# Altium schematic sheet pca64167.SchDoc
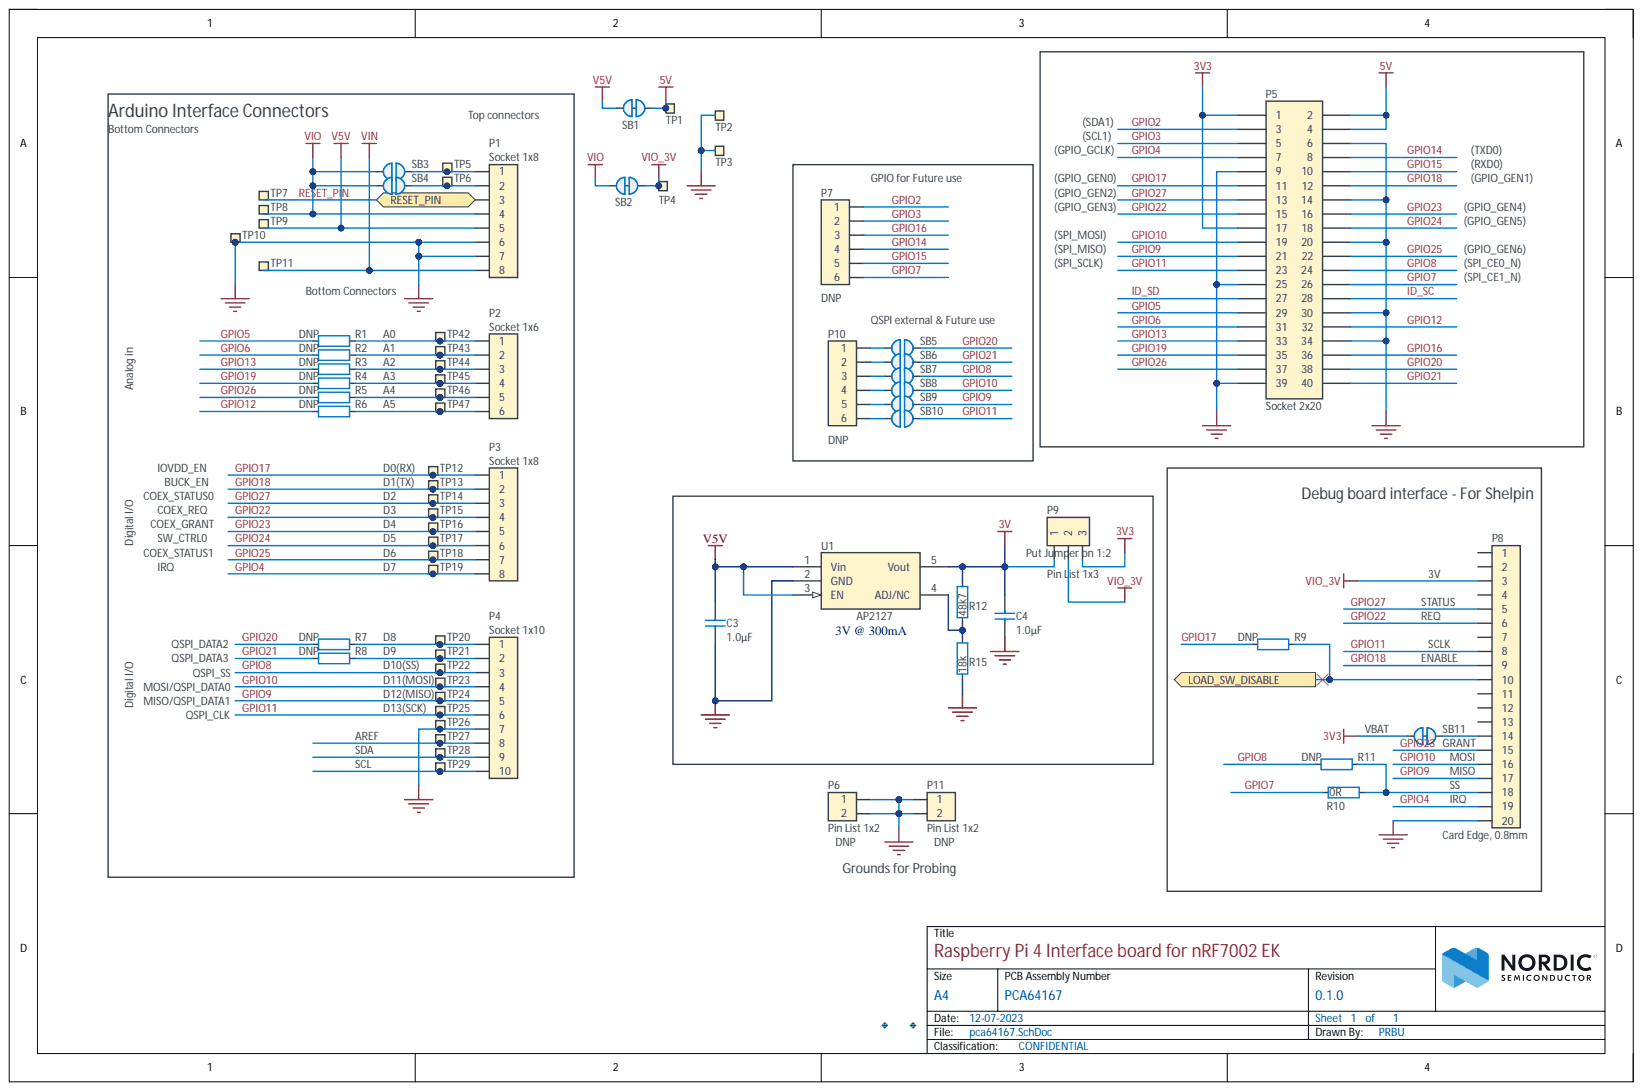
[diagram p0: pca64167.SchDoc]

## Parts
C3  1.0µF  [CAPC1005X04L]
C4  1.0µF  [CAPC1005X04L]
Fid1  Fiducial mark  [Fiducial]  (value not drawn)
Fid2  Fiducial mark  [Fiducial]  (value not drawn)
P1  Socket 1x8  [SOCKET_1x8_SMD]
P2  Socket 1x6  [SOCKET_1x6_SMD]
P3  Socket 1x8  [SOCKET_1x8_SMD]
P4  Socket 1x10  [SOCKET_1x10_SMD]
P5  Socket 2x20  [SOCKET_2x20_SMD-2.54mm]
P6  Pin List 1x2  Assembly: DNP  [LIST_1x2]
P7  Assembly: DNP  [HDR_2x3]  (hidden comment: Pin Header 2x3)
P8  Card Edge, 0.8mm  [10056847-101LF]
P9  Pin List 1x3  [LIST_1x3]
P10  Assembly: DNP  [HDR_2x3]  (hidden comment: Pin Header 2x3)
P11  Pin List 1x2  Assembly: DNP  [LIST_1x2]
R1  Assembly: DNP  [RESC1005X04L]  (hidden comment: 0R)
R2  Assembly: DNP  [RESC1005X04L]  (hidden comment: 0R)
R3  Assembly: DNP  [RESC1005X04L]  (hidden comment: 0R)
R4  Assembly: DNP  [RESC1005X04L]  (hidden comment: 0R)
R5  Assembly: DNP  [RESC1005X04L]  (hidden comment: 0R)
R6  Assembly: DNP  [RESC1005X04L]  (hidden comment: 0R)
R7  Assembly: DNP  [RESC1005X04L]  (hidden comment: 0R)
R8  Assembly: DNP  [RESC1005X04L]  (hidden comment: 0R)
R9  Assembly: DNP  [RESC1005X04L]  (hidden comment: 0R)
R10  0R  [RESC1005X04L]
R11  0R  Assembly: DNP  [RESC1005X04L]
R12  48k7  [RESC1005X04L]
R15  18k  [RESC1005X04L]
SB1  Solderbridge  [Solderbridge_Shorted_Power]  (value not drawn)
SB2  Solderbridge  [Solderbridge_Shorted_Power]  (value not drawn)
SB3  Solderbridge  [Solderbridge_0.4]  (value not drawn)  (no BOM)
SB4  Solderbridge  [Solderbridge_0.4]  (value not drawn)  (no BOM)
SB5  Solderbridge  [Solderbridge_0.4]  (value not drawn)  (no BOM)
SB6  Solderbridge  [Solderbridge_0.4]  (value not drawn)  (no BOM)
SB7  Solderbridge  [Solderbridge_0.4]  (value not drawn)  (no BOM)
SB8  Solderbridge  [Solderbridge_0.4]  (value not drawn)  (no BOM)
SB9  Solderbridge  [Solderbridge_0.4]  (value not drawn)  (no BOM)
SB10  Solderbridge  [Solderbridge_0.4]  (value not drawn)  (no BOM)
SB11  Solderbridge  [Solderbridge_Shorted_Power]  (value not drawn)
TP1  1.0mm,SMD  [TP_SMD_1.0mmX1.0mm]  (value not drawn)  (no BOM)
TP2  1.0mm,SMD  [TP_SMD_1.0mmX1.0mm]  (value not drawn)  (no BOM)
TP3  1.0mm,SMD  [TP_SMD_1.0mmX1.0mm]  (value not drawn)  (no BOM)
TP4  1.0mm,SMD  [TP_SMD_1.0mmX1.0mm]  (value not drawn)  (no BOM)
TP5  Ø1.5mm,SMD  [TP_SMD_D1.5mm]  (value not drawn)  (no BOM)
TP6  Ø1.5mm,SMD  [TP_SMD_D1.5mm]  (value not drawn)  (no BOM)
TP7  Ø1.5mm,SMD  [TP_SMD_D1.5mm]  (value not drawn)  (no BOM)
TP8  Ø1.5mm,SMD  [TP_SMD_D1.5mm]  (value not drawn)  (no BOM)
TP9  Ø1.5mm,SMD  [TP_SMD_D1.5mm]  (value not drawn)  (no BOM)
TP10  Ø1.5mm,SMD  [TP_SMD_D1.5mm]  (value not drawn)  (no BOM)
TP11  Ø1.5mm,SMD  [TP_SMD_D1.5mm]  (value not drawn)  (no BOM)
TP12  Ø1.5mm,SMD  [TP_SMD_D1.5mm]  (value not drawn)  (no BOM)
TP13  Ø1.5mm,SMD  [TP_SMD_D1.5mm]  (value not drawn)  (no BOM)
TP14  Ø1.5mm,SMD  [TP_SMD_D1.5mm]  (value not drawn)  (no BOM)
TP15  Ø1.5mm,SMD  [TP_SMD_D1.5mm]  (value not drawn)  (no BOM)
TP16  Ø1.5mm,SMD  [TP_SMD_D1.5mm]  (value not drawn)  (no BOM)
TP17  Ø1.5mm,SMD  [TP_SMD_D1.5mm]  (value not drawn)  (no BOM)
TP18  Ø1.5mm,SMD  [TP_SMD_D1.5mm]  (value not drawn)  (no BOM)
TP19  Ø1.5mm,SMD  [TP_SMD_D1.5mm]  (value not drawn)  (no BOM)
TP20  Ø1.5mm,SMD  [TP_SMD_D1.5mm]  (value not drawn)  (no BOM)
TP21  Ø1.5mm,SMD  [TP_SMD_D1.5mm]  (value not drawn)  (no BOM)
TP22  Ø1.5mm,SMD  [TP_SMD_D1.5mm]  (value not drawn)  (no BOM)
TP23  Ø1.5mm,SMD  [TP_SMD_D1.5mm]  (value not drawn)  (no BOM)
TP24  Ø1.5mm,SMD  [TP_SMD_D1.5mm]  (value not drawn)  (no BOM)
TP25  Ø1.5mm,SMD  [TP_SMD_D1.5mm]  (value not drawn)  (no BOM)
TP26  Ø1.5mm,SMD  [TP_SMD_D1.5mm]  (value not drawn)  (no BOM)
TP27  Ø1.5mm,SMD  [TP_SMD_D1.5mm]  (value not drawn)  (no BOM)
TP28  Ø1.5mm,SMD  [TP_SMD_D1.5mm]  (value not drawn)  (no BOM)
TP29  Ø1.5mm,SMD  [TP_SMD_D1.5mm]  (value not drawn)  (no BOM)
TP42  Ø1.5mm,SMD  [TP_SMD_D1.5mm]  (value not drawn)  (no BOM)
TP43  Ø1.5mm,SMD  [TP_SMD_D1.5mm]  (value not drawn)  (no BOM)
TP44  Ø1.5mm,SMD  [TP_SMD_D1.5mm]  (value not drawn)  (no BOM)
TP45  Ø1.5mm,SMD  [TP_SMD_D1.5mm]  (value not drawn)  (no BOM)
TP46  Ø1.5mm,SMD  [TP_SMD_D1.5mm]  (value not drawn)  (no BOM)
TP47  Ø1.5mm,SMD  [TP_SMD_D1.5mm]  (value not drawn)  (no BOM)
U1  AP2127  [SOT95P282X145-5N]

## Ports
LOAD_SW_DISABLE, RESET_PIN

## Nets
3V: C4.2 P9.1 R12.2 U1.5(Vout)
3V3: P5.1 P5.17 P9.3 SB11.2
5V: P5.2 P5.4 SB1.1 TP1.1
GND: C3.1 C4.1 P1.6 P1.7 P4.7 P5.6 P5.9 P5.14 P5.20 P5.25 P5.30 P5.34 P5.39 P6.1 P6.2 P8.20 P11.1 P11.2 R15.1 TP2.1 TP3.1 TP10.1 TP26.1 U1.2(GND)
V5V: C3.2 P1.5 SB1.2 TP9.1 U1.1(Vin) U1.3(EN)
VIN: P1.8 TP11.1
VIO: P1.4 SB2.2 SB3.2 SB4.2 TP8.1
VIO_3V: P8.3 P9.2 SB2.1 TP4.1
GPIO2: P5.3 P7.1
GPIO3: P5.5 P7.2
GPIO4: P3.8 P5.7 P8.19 TP19.1
GPIO5: P5.29 R1.1
GPIO6: P5.31 R2.1
GPIO7: P5.26 P7.6 R10.1
GPIO8: P4.3 P5.24 R11.1 SB7.1 TP22.1
GPIO9: P4.5 P5.21 P8.17 SB9.1 TP24.1
GPIO10: P4.4 P5.19 P8.16 SB8.1 TP23.1
GPIO11: P4.6 P5.23 P8.8 SB10.1 TP25.1
GPIO12: P5.32 R6.1
GPIO13: P5.33 R3.1
GPIO14: P5.8 P7.4
GPIO15: P5.10 P7.5
GPIO16: P5.36 P7.3
GPIO17: P3.1 P5.11 R9.1 TP12.1
GPIO18: P3.2 P5.12 P8.9 TP13.1
GPIO19: P5.35 R4.1
GPIO20: P5.38 R7.1 SB5.1
GPIO21: P5.40 R8.1 SB6.1
GPIO22: P3.4 P5.15 P8.6 TP15.1
GPIO23: P3.5 P5.16 P8.15 TP16.1
GPIO24: P3.6 P5.18 TP17.1
GPIO25: P3.7 P5.22 TP18.1
GPIO26: P5.37 R5.1
GPIO27: P3.3 P5.13 P8.5 TP14.1
ID_SC: P5.28
ID_SD: P5.27
LOAD_SW_DISABLE: P8.10 R9.2 ; port LOAD_SW_DISABLE
RESET_PIN: P1.3 TP7.1 ; port RESET_PIN
NetP1_1: P1.1 SB3.1 TP5.1
NetP1_2: P1.2 SB4.1 TP6.1
NetP2_1: P2.1 R1.2 TP42.1
NetP2_2: P2.2 R2.2 TP43.1
NetP2_3: P2.3 R3.2 TP44.1
NetP2_4: P2.4 R4.2 TP45.1
NetP2_5: P2.5 R5.2 TP46.1
NetP2_6: P2.6 R6.2 TP47.1
NetP4_1: P4.1 R7.2 TP20.1
NetP4_2: P4.2 R8.2 TP21.1
NetP4_8: P4.8 TP27.1
NetP4_9: P4.9 TP28.1
NetP4_10: P4.10 TP29.1
NetP8_14: P8.14 SB11.1
NetP8_18: P8.18 R10.2 R11.2
NetP10_1: P10.1 SB5.2
NetP10_2: P10.2 SB6.2
NetP10_3: P10.3 SB7.2
NetP10_4: P10.4 SB8.2
NetP10_5: P10.5 SB9.2
NetP10_6: P10.6 SB10.2
NetR12_1: R12.1 R15.2 U1.4(ADJ/NC)

Not connected: P8 1 2 4 7 11 12 13

## Library data of the parts
C3 = C0402-1µ0-16V-X6S-GRM155C81C105KE11J: Capacitor, Ceramic, 1.0µF 16V X6S 0402,±10%; Manufacturer: Murata; Part number NEMS: 100200493; Artikkelnr Noca: N.A.; Component Status: Active; Database Table Name: Parts$; Pad Count: 2; Component Class: Capacitors; Component Kind: Standard; ComponentLink1Description: Datasheet; ComponentLink1URL: https://www.murata.com/en-sg/products/productdetail?partno=GRM155C81C105KE11%23; ComponentLink2Description: Supplier link; ComponentLink2URL: https://www.mouser.com/ProductDetail/Murata-Electronics/GRM155C81C105KE11J?qs=qSfuJ%252Bfl%2Fd5QDM5fFpbyoA%3D%3D; Footprint Doc: 0402; HelpURL: N.A.; LatestRevisionDate: 2023-01-03; Library Name: AltiumLib.DbLib; Manufacturer Part Number: GRM155C81C105KE11J; Note: 16V; Part Number: C0402-1µ0-16V-X6S-GRM155C81C105KE11J; Part number IPS: N.A.; Part number Norautron: N.A.; PartId: 100200493; Published: 2021-12-09; Supplier 1: Mouser; Supplier 2: Digi-Key; Supplier Part Number 1: 81-GRM155C81C105KE1J; Supplier Part Number 2: 490-GRM155C81C105KE11JCT-ND; Value: 1.0µF; Author: prbu
C4 = C0402-1µ0-10V-X7S-GRM155C71A105KE11: Capacitor, Ceramic, 1.0µF 10V X7S 0402,±10%; Manufacturer: Murata; Part number NEMS: 100200497; Artikkelnr Noca: N.A.; Component Status: Active; Database Table Name: Parts$; Pad Count: 2; Component Class: Capacitors; Component Kind: Standard; ComponentLink1Description: Datasheet; ComponentLink1URL: https://www.murata.com/en-sg/products/productdetail?partno=GRM155C71A105KE11%23; ComponentLink2Description: Supplier link; ComponentLink2URL: https://www.digikey.com/en/products/detail/murata-electronics/GRM155C71A105KE11D/6606086; Footprint Doc: 0402; HelpURL: N.A.; LatestRevisionDate: 2021-12-09; Library Name: AltiumLib.DbLib; Manufacturer Part Number: GRM155C71A105KE11; Note: 10V; Part Number: C0402-1µ0-10V-X7S-GRM155C71A105KE11; Part number IPS: N.A.; Part number Norautron: N.A.; PartId: 100200497; Published: 2021-12-09; Supplier 1: Mouser; Supplier 2: Digi-Key; Supplier Part Number 1: 81-GRM155C71A105KE1J; Supplier Part Number 2: 490-14457-1-ND; Value: 1.0µF; Author: prbu
Fid1 Fid2 = Fid-Mark: Part number NEMS: 100500073; Artikkelnr Noca: N.A.; Component Status: Active; Database Table Name: Parts$; Pad Count: 1; Value: N.A.; Component Class: Connectors; Component Kind: Standard; ComponentLink1Description: Datasheet; ComponentLink1URL: N.A.; ComponentLink2Description: Supplier link; ComponentLink2URL: N.A.; Description: Fiducial Mark; Footprint Doc: Fiducial; HelpURL: N.A.; LatestRevisionDate: 2009-12-12; Library Name: AltiumLib.DbLib; Manufacturer: N.A.; Manufacturer Part Number: N.A.; Note: N.A.; Part Number: Fid-Mark; Part number IPS: N.A.; Part number Norautron: N.A.; PartId: 100500073; Published: 2009-12-12; Supplier 1: N.A.; Supplier 2: N.A.; Supplier Part Number 1: N.A.; Supplier Part Number 2: N.A.; Author: rsk
P1 P3 = Socket 1x8 SMD: Socket 1x8, 2.54mm (100mil), SMD; Manufacturer: W-CON; Manufacturer Part Number: 2171-108MS0CUNR1; Part number IPS: 00000009963; Part number NEMS: 100500412; Part number Norautron: 26032369; Artikkelnr Noca: 55030002; Component Status: Active; Database Table Name: Parts$; Note: N.A.; Pad Count: 8; System: Connector; Component Class: Connectors; Component Kind: Standard; ComponentLink1Description: Datasheet; ComponentLink1URL: N.A.; ComponentLink2Description: Supplier link; ComponentLink2URL: N.A.; Footprint Doc: SOCKET_1x8_SMD; HelpURL: N.A.; LatestRevisionDate: 2017-10-23; Library Name: AltiumLib.DbLib; Part Number: Socket 1x8 SMD; PartId: 100500412; Published: 2016-01-22; Supplier 1: Cabcon; Supplier 2: Samtec; Supplier Part Number 1: 2171-108MS0CUNR1; Supplier Part Number 2: SSM-108-F-SV-P-TR; Value: Socket 1x8; Author: rsk
P2 = Socket 1x6 SMD: Socket 1x6, 2.54mm (100mil), SMD; Manufacturer: W-CON; Manufacturer Part Number: 2171-106MS0CUNR1; Part number IPS: 00000009964; Part number NEMS: 100500408; Part number Norautron: 26032370; Artikkelnr Noca: 55030001; Component Status: Active; Database Table Name: Parts$; Note: N.A.; Pad Count: 6; System: Connector; Component Class: Connectors; Component Kind: Standard; ComponentLink1Description: Datasheet; ComponentLink1URL: N.A.; ComponentLink2Description: Supplier link; ComponentLink2URL: N.A.; Footprint Doc: SOCKET_1x6_SMD; HelpURL: N.A.; LatestRevisionDate: 2017-10-23; Library Name: AltiumLib.DbLib; Part Number: Socket 1x6 SMD; PartId: 100500408; Published: 2016-01-22; Supplier 1: Cabcon; Supplier 2: Samtec; Supplier Part Number 1: 2171-106MS0CUNR1; Supplier Part Number 2: SSM-106-F-SV-P-TR; Value: Socket 1x6; Author: rsk
P4 = Socket 1x10 SMD: Socket 1x10, 2.54mm (100mil), SMD; Manufacturer: W-CON; Manufacturer Part Number: 2171-110MS0CUNR1; Part number IPS: 00000009965; Part number NEMS: 100500416; Part number Norautron: 26032371; Artikkelnr Noca: 55030004; Component Status: Active; Database Table Name: Parts$; Note: N.A.; Pad Count: 10; System: Connector; Component Class: Connectors; Component Kind: Standard; ComponentLink1Description: Datasheet; ComponentLink1URL: N.A.; ComponentLink2Description: Supplier link; ComponentLink2URL: N.A.; Footprint Doc: SOCKET_1x10_SMD; HelpURL: N.A.; LatestRevisionDate: 2016-01-22; Library Name: AltiumLib.DbLib; Part Number: Socket 1x10 SMD; PartId: 100500416; Published: 2016-01-22; Supplier 1: Cabcon; Supplier 2: Samtec; Supplier Part Number 1: 2171-110MS0CUNR1; Supplier Part Number 2: SSM-110-F-SV-P-TR; Value: Socket 1x10; Author: rsk
P5 = Socket 2x20 SMD: Socket 2x20, 2.54mm (100mil), SMD; Manufacturer: Samtec; Manufacturer Part Number: SSW-120-22-F-D-VS-K; Part number NEMS: 100500808; Artikkelnr Noca: N.A.; Component Status: Active; Database Table Name: Parts$; Pad Count: 40; System: Connector; Component Class: Connectors; Component Kind: Standard; ComponentLink1Description: Datasheet; ComponentLink1URL: N.A.; ComponentLink2Description: Supplier link; ComponentLink2URL: N.A.; Footprint Doc: SOCKET_2x20_SMD-2.54mm; HelpURL: N.A.; LatestRevisionDate: 2023-07-03; Library Name: AltiumLib.DbLib; Note: N.A.; Part Number: Socket 2x20 SMD; Part number IPS: N.A.; Part number Norautron: N.A.; PartId: 100500808; Published: 2023-07-03; Supplier 1: Digi-Key; Supplier 2: Robu.in; Supplier Part Number 1: SAM8867-ND; Supplier Part Number 2: SKU: 535464; Value: Socket 2x20; Author: prbu
P6 P11 = Pin List 1x2: Pin List 1x2, 2.54mm (100mil); Manufacturer: N.A.; Part number NEMS: 100500297; Artikkelnr Noca: 56019040; Component Status: Active; Database Table Name: Parts$; Pad Count: 2; System: Connector; Component Class: Connectors; Component Kind: Standard; ComponentLink1Description: Datasheet; ComponentLink1URL: N.A.; ComponentLink2Description: Supplier link; ComponentLink2URL: N.A.; Footprint Doc: LIST_1x2; HelpURL: N.A.; LatestRevisionDate: 2009-12-12; Library Name: AltiumLib.DbLib; Manufacturer Part Number: N.A.; Note: N.A.; Part Number: Pin List 1x2; Part number IPS: N.A.; Part number Norautron: N.A.; PartId: 100500297; Published: 2009-12-12; Supplier 1: N.A.; Supplier 2: N.A.; Supplier Part Number 1: N.A.; Supplier Part Number 2: N.A.; Value: Pin List 1x2; Author: rsk
P7 P10 = Pin Header 2x3: Pin Header 2x3, 2.54mm (100mil); Part number NEMS: 100500239; Artikkelnr Noca: N.A.; Component Status: Active; Database Table Name: Parts$; Pad Count: 6; System: Connector; Component Class: Connectors; Component Kind: Standard; ComponentLink1Description: Datasheet; ComponentLink1URL: N.A.; ComponentLink2Description: Supplier link; ComponentLink2URL: N.A.; Footprint Doc: HDR_2x3; HelpURL: N.A.; LatestRevisionDate: 2009-12-12; Library Name: AltiumLib.DbLib; Manufacturer: N.A.; Manufacturer Part Number: N.A.; Note: N.A.; Part Number: Pin Header 2x3; Part number IPS: N.A.; Part number Norautron: N.A.; PartId: 100500239; Published: 2009-12-12; Supplier 1: N.A.; Supplier 2: N.A.; Supplier Part Number 1: N.A.; Supplier Part Number 2: N.A.; Value: Pin Header 2x3; Author: rsk
P8 = 10056847-101LF: 0.8mm pitch, dual edge, right angle, SMD, card edge connector; Manufacturer: FCI; Part number NEMS: 100500633; Artikkelnr Noca: N.A.; Component Status: Active; Database Table Name: Parts$; Pad Count: 20; Component Class: Connectors; Component Kind: Standard; ComponentLink1Description: Datasheet; ComponentLink1URL: https://www.amphenol-icc.com/media/wysiwyg/files/drawing/10056847.pdf; ComponentLink2Description: Supplier link; ComponentLink2URL: N.A.; Footprint Doc: 10056847-101LF; HelpURL: N.A.; LatestRevisionDate: 2018-05-07; Library Name: AltiumLib.DbLib; Manufacturer Part Number: 10056847-101LF; Note: N.A.; Part Number: 10056847-101LF; Part number IPS: N.A.; Part number Norautron: N.A.; PartId: 100500633; Published: 2018-05-07; Supplier 1: Digi-Key; Supplier 2: N.A.; Supplier Part Number 1: 609-4943-1-ND; Supplier Part Number 2: N.A.; System: Connector; Value: Card Edge, 0.8mm; Author: rsk
P9 = Pin List 1x3: Pin List 1x3, 2.54mm (100mil); Manufacturer: N.A.; Part number NEMS: 100500304; Artikkelnr Noca: 56019041; Component Status: Active; Database Table Name: Parts$; Pad Count: 3; System: Connector; Component Class: Connectors; Component Kind: Standard; ComponentLink1Description: Datasheet; ComponentLink1URL: N.A.; ComponentLink2Description: Supplier link; ComponentLink2URL: N.A.; Footprint Doc: LIST_1x3; HelpURL: N.A.; LatestRevisionDate: 2009-12-12; Library Name: AltiumLib.DbLib; Manufacturer Part Number: N.A.; Note: N.A.; Part Number: Pin List 1x3; Part number IPS: N.A.; Part number Norautron: N.A.; PartId: 100500304; Published: 2009-12-12; Supplier 1: N.A.; Supplier 2: N.A.; Supplier Part Number 1: N.A.; Supplier Part Number 2: N.A.; Value: Pin List 1x3; Author: rsk
R1-R11 = R0402-0R: Resistor, ±5%, 0.063W; Manufacturer: N.A.; Part number IPS: 00000007128; Part number NEMS: 100100109; Artikkelnr Noca: 27049000; Component Status: Active; Database Table Name: Parts$; Note: Chip Res 5%; Pad Count: 2; Component Class: Resistors; Component Kind: Standard; ComponentLink1Description: Datasheet; ComponentLink1URL: N.A.; ComponentLink2Description: Supplier link; ComponentLink2URL: N.A.; Footprint Doc: 0402; HelpURL: N.A.; LatestRevisionDate: 2009-11-23; Library Name: AltiumLib.DbLib; Manufacturer Part Number: N.A.; Part Number: R0402-0R; Part number Norautron: N.A.; PartId: 100100109; Published: 2009-05-08; Supplier 1: Farnell; Supplier 2: N.A.; Supplier Part Number 1: 146-9661; Supplier Part Number 2: N.A.; Value: 0R; Author: rsk
R12 = R0402-48k7: Resistor, ±1%, 0.063W; Manufacturer: Yageo; Manufacturer Part Number: RC0402FR-0748K7L; Part number NEMS: 100100646; Artikkelnr Noca: N.A.; Component Status: Active; Database Table Name: Parts$; Note: Chip Res 1%; Pad Count: 2; Component Class: Resistors; Component Kind: Standard; ComponentLink1Description: Datasheet; ComponentLink1URL: N.A.; ComponentLink2Description: Supplier link; ComponentLink2URL: N.A.; Footprint Doc: 0402; HelpURL: N.A.; LatestRevisionDate: 2021-11-12; Library Name: AltiumLib.DbLib; Part Number: R0402-48k7; Part number IPS: N.A.; Part number Norautron: N.A.; PartId: 100100646; Published: 2021-11-12; Supplier 1: Mouser; Supplier 2: Digi-Key; Supplier Part Number 1: 603-RC0402FR-0748K7L; Supplier Part Number 2: YAG3169CT-ND; Value: 48k7; Author: kjp
R15 = R0402-18k: Resistor, ±1%, 0.063W; Manufacturer: N.A.; Part number IPS: 00000003300; Part number NEMS: 100100208; Artikkelnr Noca: 27049103; Component Status: Active; Database Table Name: Parts$; Note: Chip Res 1%; Pad Count: 2; Component Class: Resistors; Component Kind: Standard; ComponentLink1Description: Datasheet; ComponentLink1URL: N.A.; ComponentLink2Description: Supplier link; ComponentLink2URL: N.A.; Footprint Doc: 0402; HelpURL: N.A.; LatestRevisionDate: 2009-11-23; Library Name: AltiumLib.DbLib; Manufacturer Part Number: N.A.; Part Number: R0402-18k; Part number Norautron: N.A.; PartId: 100100208; Published: 2009-05-08; Supplier 1: Farnell; Supplier 2: N.A.; Supplier Part Number 1: 173-8867; Supplier Part Number 2: N.A.; Value: 18k; Author: rsk
SB1 SB2 SB11 = Solderbridge Shorted Power: Shorted Solderbridge Power; Part number NEMS: 100500460; Artikkelnr Noca: N.A.; Component Status: Active; Database Table Name: Parts$; Pad Count: 2; System: Connector; Component Class: Connectors; Component Kind: Net Tie; ComponentLink1Description: Datasheet; ComponentLink1URL: N.A.; ComponentLink2Description: Supplier link; ComponentLink2URL: N.A.; Footprint Doc: Solderbridge_Shorted_Power; HelpURL: N.A.; LatestRevisionDate: 2012-08-07; Library Name: AltiumLib.DbLib; Manufacturer: N.A.; Manufacturer Part Number: N.A.; Note: N.A.; Part Number: Solderbridge Shorted Power; Part number IPS: N.A.; Part number Norautron: N.A.; PartId: 100500460; Published: 2012-08-07; Supplier 1: N.A.; Supplier 2: N.A.; Supplier Part Number 1: N.A.; Supplier Part Number 2: N.A.; Value: Solderbridge; Author: rsk
SB3-SB10 = Solderbridge 0.4mm: Part number NEMS: 100500560; Artikkelnr Noca: N.A.; Component Status: Active; Database Table Name: Parts$; Pad Count: 2; System: Connector; Component Class: Connectors; ComponentLink1Description: Datasheet; ComponentLink1URL: N.A.; ComponentLink2Description: Supplier link; ComponentLink2URL: N.A.; Description: Solderbridge; Footprint Doc: Solderbridge; HelpURL: N.A.; LatestRevisionDate: 2009-12-14; Library Name: AltiumLib.DbLib; Manufacturer: N.A.; Manufacturer Part Number: N.A.; Note: N.A.; Part Number: Solderbridge 0.4mm; Part number IPS: N.A.; Part number Norautron: N.A.; PartId: 100500560; Published: 2009-12-14; Supplier 1: N.A.; Supplier 2: N.A.; Supplier Part Number 1: N.A.; Supplier Part Number 2: N.A.; Value: Solderbridge; Author: rsk
TP1-TP4 = TP_SMD_1.0MM: 1.0x1.0mm SMD testpad; Manufacturer: Nordic Semiconductor; Part number NEMS: 100500469; Artikkelnr Noca: N.A.; Component Status: Active; Database Table Name: Parts$; Pad Count: 1; System: Connector; Component Class: Connectors; ComponentLink1Description: Datasheet; ComponentLink1URL: N.A.; ComponentLink2Description: Supplier link; ComponentLink2URL: N.A.; Footprint Doc: TP_SMD_1.0mmX1.0mm; HelpURL: N.A.; LatestRevisionDate: 2009-12-12; Library Name: AltiumLib.DbLib; Manufacturer Part Number: N.A.; Note: N.A.; Part Number: TP_SMD_1.0MM; Part number IPS: N.A.; Part number Norautron: N.A.; PartId: 100500469; Published: 2009-12-12; Supplier 1: N.A.; Supplier 2: N.A.; Supplier Part Number 1: N.A.; Supplier Part Number 2: N.A.; Value: 1.0mm,SMD; Author: rsk
TP5-TP29 TP42-TP47 = TP_SMD_Ø1.5MM: 1.5mm circular SMD testpad; Manufacturer: Nordic Semiconductor; Artikkelnr Noca: N.A.; Database Table Name: Parts$; Pad Count: 1; Component Class: Connectors; ComponentLink1Description: Datasheet; ComponentLink1URL: N.A.; ComponentLink2Description: Supplier link; ComponentLink2URL: N.A.; Footprint Doc: TP_SMD_Ø1.5mm; HelpURL: N.A.; LatestRevisionDate: 2021-03-05; Library Name: AltiumLib.DbLib; Manufacturer Part Number: N.A.; Note: N.A.; Part Number: TP_SMD_Ø1.5MM; Part number IPS: N.A.; Part number Norautron: N.A.; PartId: 100500477; Published: 2016-06-02; Supplier 1: N.A.; Supplier 2: N.A.; Supplier Part Number 1: N.A.; Supplier Part Number 2: N.A.; Value: Ø1.5mm,SMD; Author: rsk
U1 = AP2127K-ADJTRG1: IC REG LDO ADJ 0.3A SOT23-5; Manufacturer: Diodes Incorporated; Artikkelnr Noca: N.A.; Database Table Name: Parts$; Pad Count: 5; Component Class: Misc_ICs; Component Kind: Standard; ComponentLink1Description: Datasheet; ComponentLink1URL: N.A.; ComponentLink2Description: Supplier link; ComponentLink2URL: N.A.; Footprint Doc: SOT23-5; HelpURL: https://www.diodes.com/assets/Datasheets/AP2127.pdf; LatestRevisionDate: 2017-07-20; Library Name: AltiumLib.DbLib; Manufacturer Part Number: AP2127K-ADJTRG1; Note: N.A.; Part Number: AP2127K-ADJTRG1; Part number IPS: N.A.; Part number Norautron: N.A.; PartId: 101900493; Published: 2017-07-20; Supplier 1: Digi-Key; Supplier 2: N.A.; Supplier Part Number 1: AP2127K-ADJTRG1DITR-ND; Supplier Part Number 2: N.A.; Value: AP2127; Author: rsk
